# Altium schematic sheet Power Supply.SchDoc
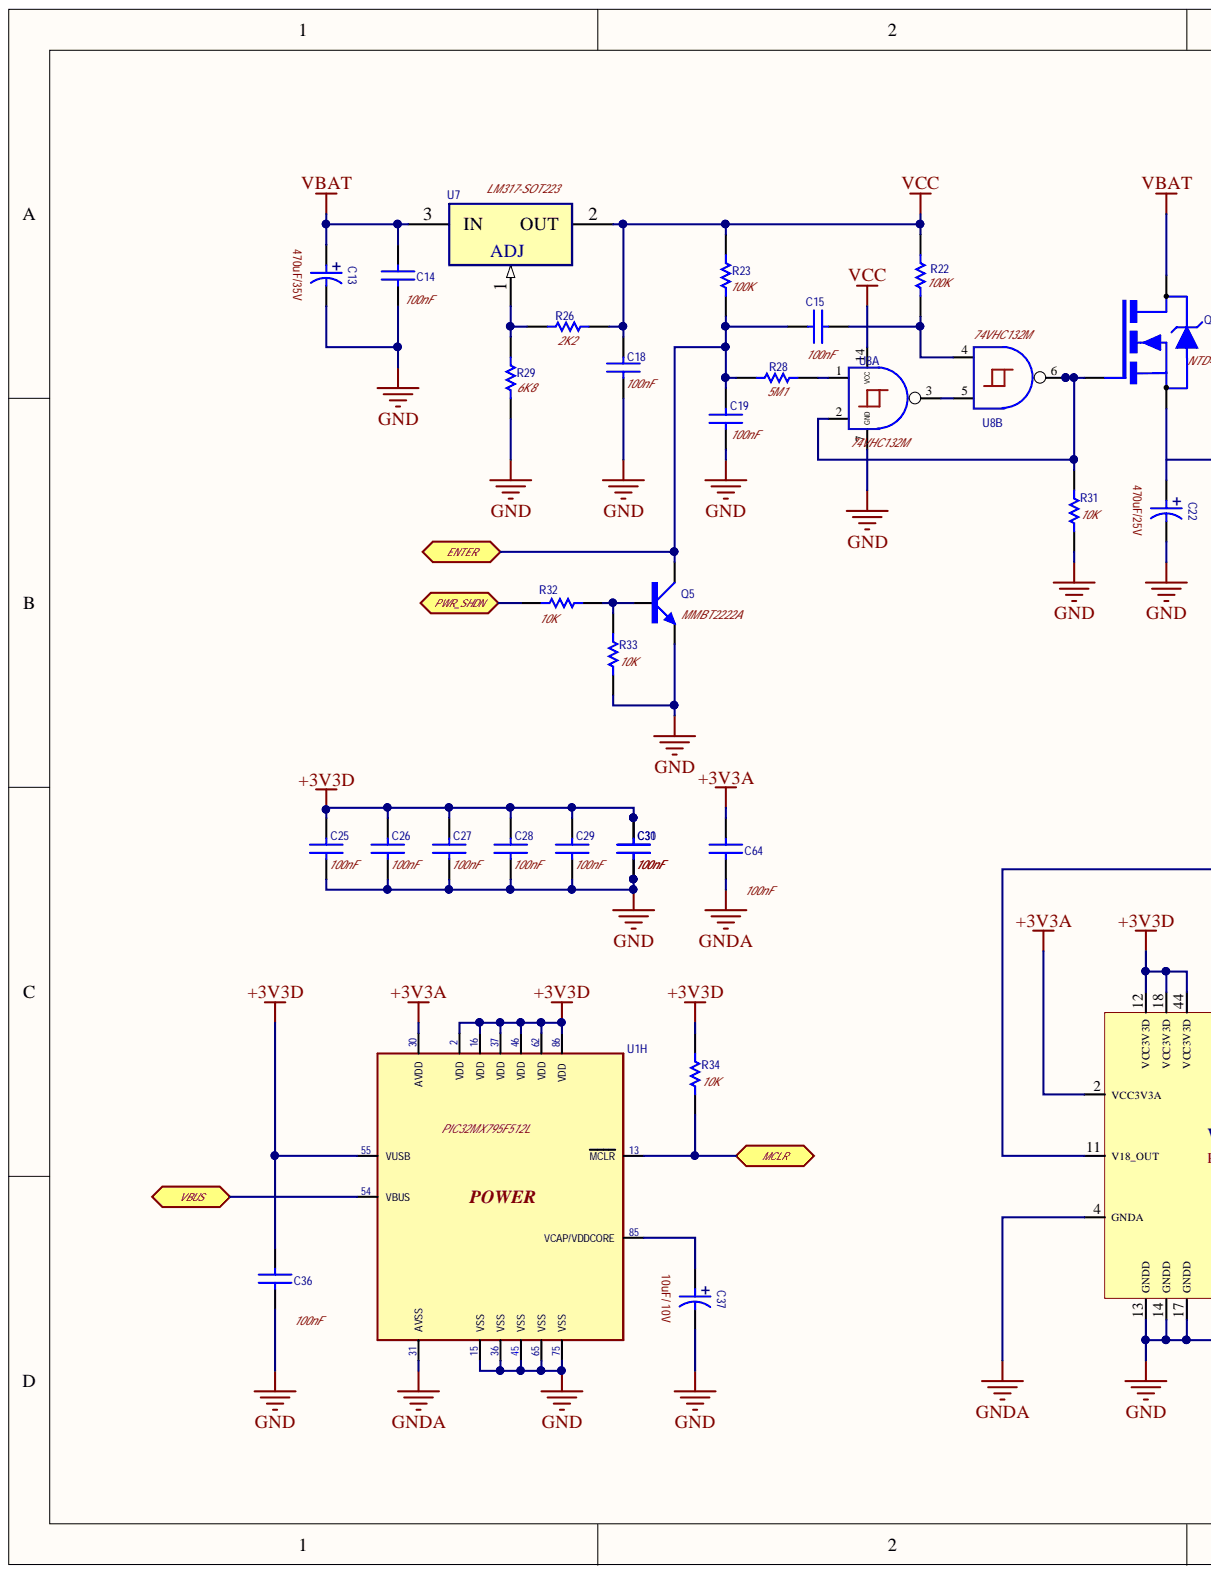
[diagram p0: Power Supply.SchDoc, left]
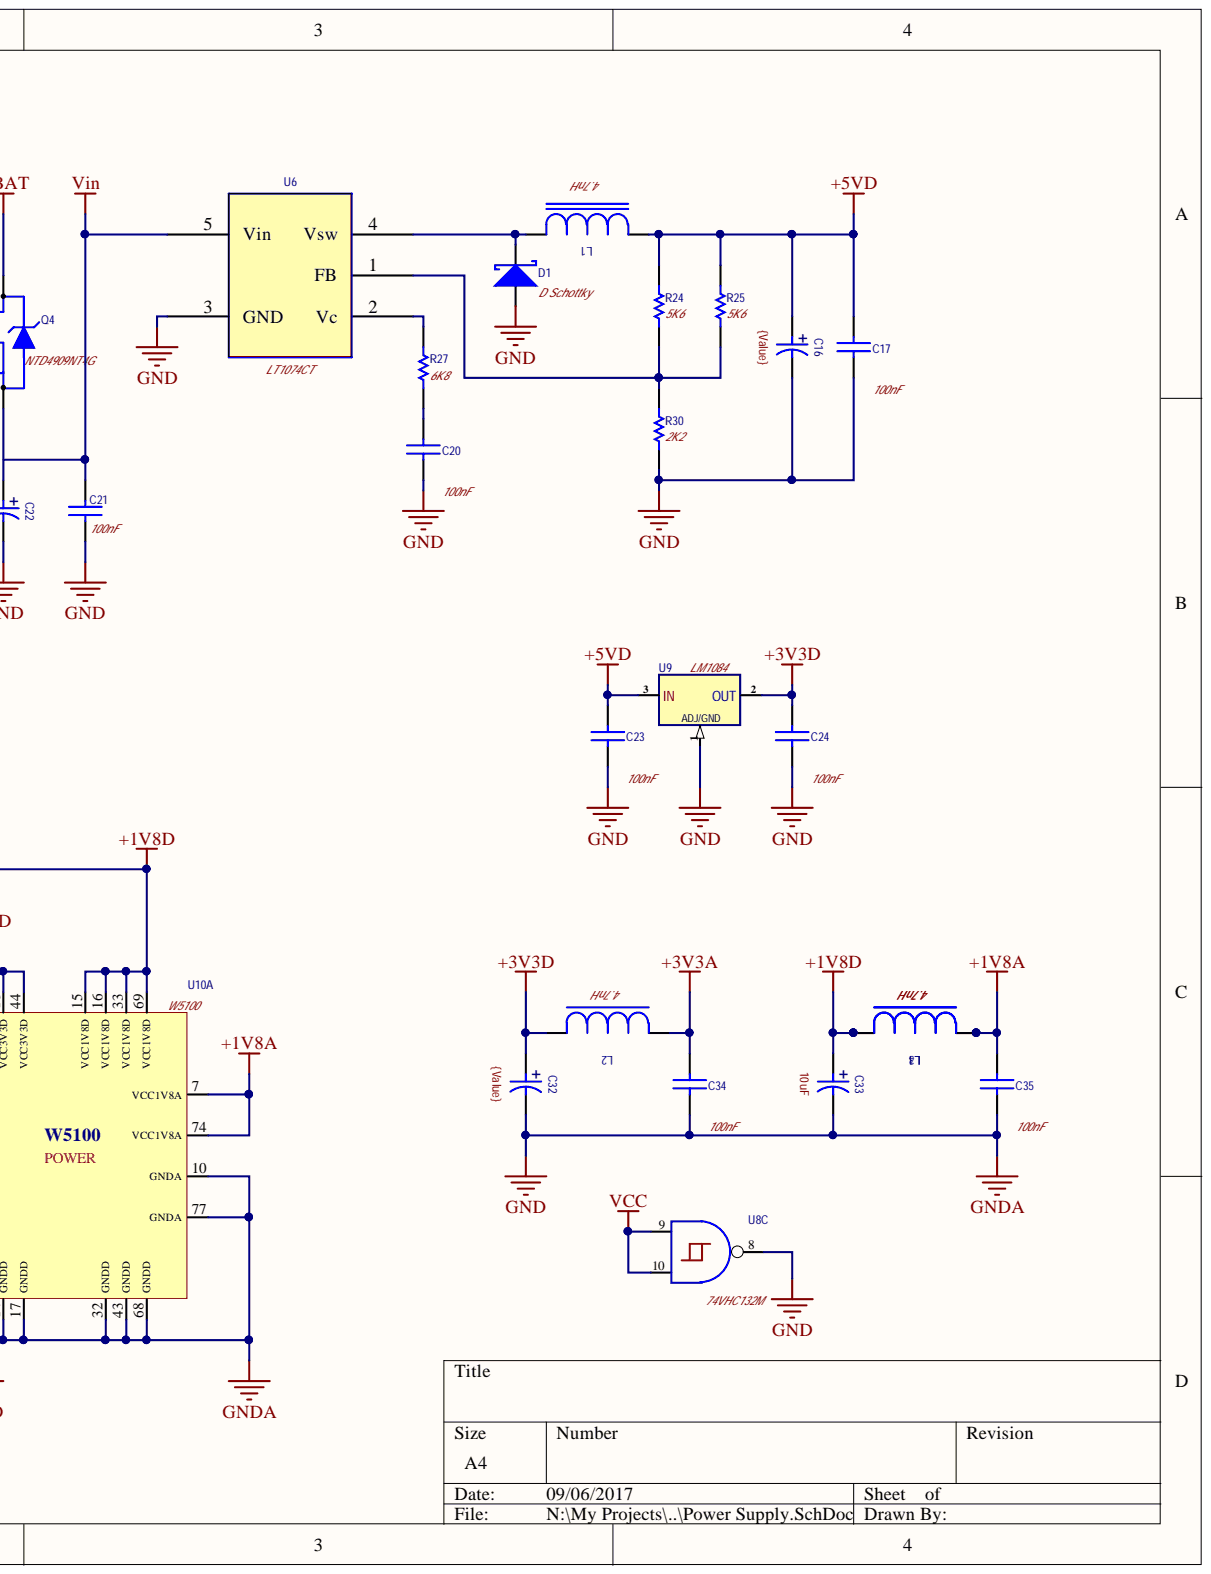
[diagram p1: Power Supply.SchDoc, right]

## Parts
C13  value 470uF/35V  [C-TANT#C]  (hidden comment: Cap Tantalum TH)
C14  value 100nF  [C - 0805]  (hidden comment: Cap Semi 0805)
C15  value 100nF  [Cap - Wima 60x250x700]  (hidden comment: Wima Capacitor)
C16  value {Value}  [C-TANT#D]  (hidden comment: Cap Tantalum TH)
C17  value 100nF  [C-0805]  (hidden comment: Cap 0805)
C18  value 100nF  [C - 0805]  (hidden comment: Cap Semi 0805)
C19  value 100nF  [C - 0805]  (hidden comment: Cap Semi 0805)
C20  value 100nF  [Cap - Wima 60x250x700]  (hidden comment: Wima Capacitor)
C21  value 100nF  [C - 0805]  (hidden comment: Cap Semi 0805)
C22  value 470uF/25V  [470 uF 10x10.5 HT]  (hidden comment: Cap Elect_SMD 470 uF 10x10.5 HT)
C23  value 100nF  [C-0805]  (hidden comment: Cap 0805)
C24  value 100nF  [C-0805]  (hidden comment: Cap 0805)
C25  value 100nF  [C - 0805]  (hidden comment: Cap Semi 0805)
C26  value 100nF  [C - 0805]  (hidden comment: Cap Semi 0805)
C27  value 100nF  [C - 0805]  (hidden comment: Cap Semi 0805)
C28  value 100nF  [C - 0805]  (hidden comment: Cap Semi 0805)
C29  value 100nF  [C - 0805]  (hidden comment: Cap Semi 0805)
C30  value 100nF  [C - 0805]  (hidden comment: Cap Semi 0805)
C31  value 100nF  [C - 0805]  (hidden comment: Cap Semi 0805)
C32  value {Value}  [C-TANT#A]  (hidden comment: Cap Tantalum TH)
C33  value 10uF  [C-TANT#A]  (hidden comment: Cap Tantalum TH)
C34  value 100nF  [C - 0805]  (hidden comment: Cap Semi 0805)
C35  value 100nF  [C - 0805]  (hidden comment: Cap Semi 0805)
C36  value 100nF  [C - 0805]  (hidden comment: Cap Semi 0805)
C37  value 10uF/ 10V  [C-TANT#B -  10uF 10V]  (hidden comment: Cap Tantalum TH)
C64  value 100nF  [C - 0805]  (hidden comment: Cap Semi 0805)
D1  D Schottky  [Shottkey TH]
L1  value 4.7nH  [Coilcraft LP]  (hidden comment: INDUCTOR SMT Coilcraft)
L2  value 4.7nH  [L-0805]  (hidden comment: Ferrire Bead-SMT 0805)
L3  value 4.7nH  [L-0805]  (hidden comment: Ferrire Bead-SMT 0805)
L4  value 4.7nH  [L-0805]  (hidden comment: Ferrire Bead-SMT 0805)
Q4  NTD4909NT4G  [DPAK]
Q5  MMBT2222A  [SOT-23]
R22  value 100K  [R-0603]  (hidden comment: Resistor - SMD 0603)
R23  value 100K  [R-0603]  (hidden comment: Resistor - SMD 0603)
R24  value 5K6  [R-0805 - 5K6]  (hidden comment: Resistor - SMD 0805)
R25  value 5K6  [R-0805 - 5K6]  (hidden comment: Resistor - SMD 0805)
R26  value 2K2  [R-0603]  (hidden comment: Resistor - SMD 0603)
R27  value 6K8  [R-0805 - 6K8]  (hidden comment: Resistor - SMD 0805)
R28  value 5M1  [R-0603]  (hidden comment: Resistor - SMD 0603)
R29  value 6K8  [R-0603]  (hidden comment: Resistor - SMD 0603)
R30  value 2K2  [R-0805 - 2K2]  (hidden comment: Resistor - SMD 0805)
R31  value 10K  [R-0805 - 10K]  (hidden comment: Resistor - SMD 0603)
R32  value 10K  [R-0805 - 10K]  (hidden comment: Resistor - SMD 0805)
R33  value 10K  [R-0805 - 10K]  (hidden comment: Resistor - SMD 0805)
R34  value 10K  [R-0805 - 10K]  (hidden comment: Resistor - SMD 0805)
U1  PIC32MX795F512L-PT  value PIC32MX795F512L  [TQFP-PF100_N]  (part H)
U6  LT1074CT  [LT1074_TO-220-5_H]
U7  LM317-SOT223  [LM317_SOT-223]
U8  74VHC132M  [SO14]  (parts A,B,C)
U9  LM1084  [TO-263 - LM1084]
U10  W5100  [W5100]  (part A)

## Ports
ENTER, MCLR, PWR_SHDN, VBUS

## Nets
2: U9.4
+1V8A: C35.2 L3.1 L4.1 U10.7(VCC1V8A) U10.74(VCC1V8A)
+1V8D: C33.PLUS L3.2 L4.2 U10.11(V18_OUT) U10.15(VCC1V8D) U10.16(VCC1V8D) U10.33(VCC1V8D) U10.69(VCC1V8D)
+3V3A: C34.2 C64.2 L2.1 U1.30(AVDD) U10.2(VCC3V3A)
+3V3D: C24.2 C25.2 C26.2 C27.2 C28.2 C29.2 C30.2 C31.2 C32.PLUS C36.2 L2.2 R34.2 U1.2(VDD) U1.16(VDD) U1.37(VDD) U1.46(VDD) U1.55(VUSB) U1.62(VDD) U1.86(VDD) U9.2 U10.12(VCC3V3D) U10.18(VCC3V3D) U10.44(VCC3V3D)
+5VD: C16.PLUS C17.2 C23.2 L1.1 R24.2 R25.2 U9.3
GND: C13.MINUS C14.1 C16.MINUS C17.1 C18.1 C19.1 C20.1 C21.1 C22.MINUS C23.1 C24.1 C25.1 C26.1 C27.1 C28.1 C29.1 C30.1 C31.1 C32.MINUS C33.MINUS C34.1 C35.1 C36.1 C37.MINUS C64.1 D1.A Q5.2 R29.2 R30.1 R31.1 R33.1 U1.15(VSS) U1.31(AVSS) U1.36(VSS) U1.45(VSS) U1.65(VSS) U1.75(VSS) U6.3(GND) U8.7(GND) U8.8 U9.1 U10.4(GNDA) U10.10(GNDA) U10.13(GNDD) U10.14(GNDD) U10.17(GNDD) U10.32(GNDD) U10.43(GNDD) U10.68(GNDD) U10.77(GNDA)
VBAT: C13.PLUS C14.2 Q4.3 U7.3
VCC: C18.2 R22.2 R23.2 R26.1 U7.2 U8.9 U8.10 U8.14(VCC)
Vin: C21.2 U6.5(Vin)
ENTER: C15.2 C19.2 Q5.3 R23.1 R28.1 ; port ENTER
MCLR: R34.1 U1.13(MCLR) ; port MCLR
PWR_SHDN: R32.1 ; port PWR_SHDN
VBUS: U1.54(VBUS) ; port VBUS
NetC15_1: C15.1 R22.1 U8.4
NetC20_2: C20.2 R27.1
NetC22_PLUS: C22.PLUS Q4.2
NetC37_PLUS: C37.PLUS U1.85(VCAP/VDDCORE)
NetD1_K: D1.K L1.2 U6.4(Vsw)
NetQ4_1: Q4.1 R31.2 U8.2 U8.6
NetQ5_1: Q5.1 R32.2 R33.2
NetR24_1: R24.1 R25.1 R30.2 U6.1(FB)
NetR26_2: R26.2 R29.1 U7.1
NetR27_2: R27.2 U6.2(Vc)
NetR28_2: R28.2 U8.1
NetU8_3: U8.3 U8.5

## Library data of the parts
C13 = C-TANT#C: Tantalum Capacitor SMD; Component Kind: Standard; Library Name: MKM Capacitors.IntLib
C14 C17-C19 C21 C23 C24 C34-C36 C64 = Cap 0805: Capacitor SMD; Component Kind: Standard; LatestRevisionDate: 17-Jul-2002; LatestRevisionNote: Re-released for DXP Platform.; Published: 8-Jun-2000; Library Name: MKM Capacitors.IntLib; Publisher: Altium Limited
  C14 C18 C19 C21: PackageReference: C 0805
  C17 C23 C24 C34-C36 C64: PackageReference: C0805
C15 C20 = Cap-Wima 60x250x700: Component Kind: Standard; Description: Wima Capacitor; LatestRevisionDate: 17-Jul-2002; LatestRevisionNote: Re-released for DXP Platform.; PackageReference: C1206; Published: 8-Jun-2000; Library Name: MKM Capacitors.IntLib; Publisher: Altium Limited
C16 = C-TANT#D: Tantalum Capacitor SMD; Component Kind: Standard; Library Name: MKM Capacitors.IntLib
C22 = Cap Elect_SMD 470 uF 10x10.5 HT: SMD Electrolytic Capacitor; Component Kind: Standard; Library Name: MKM Capacitors.IntLib
C25-C31 = Cap 0603: Capacitor SMD; Component Kind: Standard; LatestRevisionDate: 17-Jul-2002; LatestRevisionNote: Re-released for DXP Platform.; PackageReference: C 0805; Published: 8-Jun-2000; Library Name: MKM Capacitors.IntLib; Publisher: Altium Limited
C32 C33 = C-TANT#A: Tantalum Capacitor SMD; Component Kind: Standard; Library Name: MKM Capacitors.IntLib
C37 = C-TANT 10uF/ 10V #B: Tantalum Capacitor SMD; Component Kind: Standard; Library Name: MKM Capacitors.IntLib
D1 = D Schottky: Schottky Diode; Code_JEDEC: DO-214-AA; Component Kind: Standard; LatestRevisionDate: 17-Jul-2002; LatestRevisionNote: Re-released for DXP Platform.; PackageReference: SMB; Published: 8-Jun-2000; Signal Integrity: D Schottky; Simulation: SKYDIODE; Library Name: MKM Diodes.IntLib; Publisher: Altium Limited
L1 = INDUCTOR SMT Coilcraft: INDUCTOR-100uH SMT; Component Kind: Standard; Library Name: MKM Inductance_transformers.IntLib
L2-L4 = Ferrire Bead-SMT 0805: Ferrire Bead -SMT 0805; Component Kind: Standard; Library Name: MKM Inductance_transformers.IntLib
Q5 = MMBT2222A: NPN Switching Transistor; Component Kind: Standard; ComponentLink1Description: Manufacturer Link; ComponentLink1URL: http://www.semiconductors.philips.com/; ComponentLink2Description: Datasheet; ComponentLink2URL: http://www.semiconductors.philips.com/acrobat/datasheets/MMBT2222A_2.pdf; DatasheetVersion: 11-Apr-2000; LatestRevisionDate: 12-Dec-2006; LatestRevisionNote: IPC-7351 Footprint Added.; PackageDescription: 3-Pin SOT-23 Package 0.95 mm Pitch, 2.3 mm Lead Span; PackageReference: SOT23; PackageVersion: May-1999; Published: 17-Sep-2003; Signal Integrity: MMBT2222A; Simulation: QMMBT2222A; Library Name: MKM Transistors.IntLib; Publisher: Altium Limited
R22 R23 = R0805- 100K: Component Kind: Standard; Description: Resistor - SMD 0603; LatestRevisionDate: 17-Jul-2002; LatestRevisionNote: Re-released for DXP Platform.; PackageReference: AXIAL-0.3; Published: 8-Jun-2000; Signal Integrity: Res; Simulation: RESISTOR; Library Name: MKM Resistors.IntLib; Publisher: Altium Limited
R24 R25 = R0805- 5K6: Component Kind: Standard; Description: Resistor - SMD 0805; LatestRevisionDate: 17-Jul-2002; LatestRevisionNote: Re-released for DXP Platform.; PackageReference: AXIAL-0.3; Published: 8-Jun-2000; Signal Integrity: Res; Simulation: RESISTOR; Library Name: MKM Resistors.IntLib; Publisher: Altium Limited
R26 R30 = R0805- 2K2: Component Kind: Standard; LatestRevisionDate: 17-Jul-2002; LatestRevisionNote: Re-released for DXP Platform.; PackageReference: AXIAL-0.3; Published: 8-Jun-2000; Signal Integrity: Res; Simulation: RESISTOR; Library Name: MKM Resistors.IntLib; Publisher: Altium Limited
  R26: Description: Resistor - SMD 0603
  R30: Description: Resistor - SMD 0805
R27 R29 = R0805- 6K8: Component Kind: Standard; LatestRevisionDate: 17-Jul-2002; LatestRevisionNote: Re-released for DXP Platform.; PackageReference: AXIAL-0.3; Published: 8-Jun-2000; Signal Integrity: Res; Simulation: RESISTOR; Library Name: MKM Resistors.IntLib; Publisher: Altium Limited
  R27: Description: Resistor - SMD 0805
  R29: Description: Resistor - SMD 0603
R28 R31 = R0805- 5M1: Component Kind: Standard; Description: Resistor - SMD 0603; LatestRevisionDate: 17-Jul-2002; LatestRevisionNote: Re-released for DXP Platform.; PackageReference: AXIAL-0.3; Published: 8-Jun-2000; Signal Integrity: Res; Simulation: RESISTOR; Library Name: MKM Resistors.IntLib; Publisher: Altium Limited
R32-R34 = R0805- 10K: Component Kind: Standard; Description: Resistor - SMD 0805; LatestRevisionDate: 17-Jul-2002; LatestRevisionNote: Re-released for DXP Platform.; PackageReference: AXIAL-0.3; Published: 8-Jun-2000; Signal Integrity: Res; Simulation: RESISTOR; Library Name: MKM Resistors.IntLib; Publisher: MKM Technologies
U1 = PIC32MX7X5F*L-PT: High Performance, USB, CAN and Ethernet 32-Bit Flash Microcontroller; Component Kind: Standard; Library Name: MKM ICs.IntLib
U6 = LT1074CT- Horiz: Component Kind: Standard; Description: LT1074CT; Library Name: MKM Voltage regulators.IntLib
U7 = LM317-SOT223: Adjustable Regulator with 3.7 to 38 V Input and 1.2 to 32 V Output, -40 to 125 degC,; Manufacturer: Texas Instruments; PartNumber: LM317KC; Eco-Plan: Pb-Free (RoHS); Iout(Max)(A): 0.1; Vin(Max)(V): 38; Vin(Min)(V): 3.7; Vout(Max)(V): 32; Vout(Min)(V): 1.2; Code_JEDEC: TO-226-AA; Component Kind: Standard; ComponentLink1Description: Datasheet; ComponentLink1URL: http://www.ti.com/general/docs/lit/getliterature.tsp?genericPartNumber=LM317L&fileType=pdf; ComponentLink2Description: Package Specification; ComponentLink2URL: http://www.ti.com/litv/pdf/msot002a; ComponentLink3Description: URL Link1; ComponentLink3URL: Samples URL:http://www.ti.com/product/lm317l#samples; DatasheetVersion: SLCS144D; Mounting Technology: Through Hole; PackageDescription: Plastic Cylindrical Package, Formed Lead Option (TO-92); PackageReference: LP3; PackageVersion: revC, Oct-2001; Supplier 1: Digi-Key; Supplier 2: Mouser; Supplier Part Number 1: LM317LILPRE3-ND; Supplier Part Number 2: 595-LM317LILPRE3; Library Name: MKM Voltage regulators.IntLib
U8 = 74VHC132M: QUAD 2-Input NAND Schmitt Trigger; Assy: Loaded; CH: WL-07-2004; CR: WL; Vendor: ST; ComponentHeight: 1.75mm; Component Kind: Standard; VendorP/N: 74VHC132M; Library Name: MKM ICs.IntLib
U9 = LM1084 - DPAK: Adjustable Regulator with 3.7 to 38 V Input and 1.2 to 32 V Output, -40 to 125 degC, 3-Pin TO-92 (LP), Pb-Free (RoHS); Manufacturer: Texas Instruments; PartNumber: LM317LILPRE3; Iout(Max)(A): 0.1; Vin(Max)(V): 38; Vin(Min)(V): 3.7; Vout(Max)(V): 32; Vout(Min)(V): 1.2; Code_JEDEC: TO-226-AA; Component Kind: Standard; ComponentLink1Description: Datasheet; ComponentLink1URL: http://www.ti.com/general/docs/lit/getliterature.tsp?genericPartNumber=LM317L&fileType=pdf; ComponentLink2Description: Package Specification; ComponentLink2URL: http://www.ti.com/litv/pdf/msot002a; ComponentLink3Description: URL Link1; ComponentLink3URL: Samples URL:http://www.ti.com/product/lm317l#samples; DatasheetVersion: SLCS144D; Eco-Plan: Pb-Free (RoHS); Mounting Technology: Through Hole; PackageDescription: Plastic Cylindrical Package, Formed Lead Option (TO-92); PackageReference: LP3; PackageVersion: revC, Oct-2001; Supplier 1: Digi-Key; Supplier 2: Mouser; Supplier Part Number 1: LM317LILPRE3-ND; Supplier Part Number 2: 595-LM317LILPRE3; Library Name: MKM Voltage regulators.IntLib
Q4 = NTD4909NT4G: Component Kind: Standard; Description: NTD4909NT4G; Value: NTD4909NT4G; Library Name: MKM Transistors.IntLib
U10 = W5100: Component Kind: Standard; Library Name: MKM Tech ICs.IntLib
